# Altium schematic sheet Teensy 3.6 with J1939 with NFETs.SchDoc("Teensy 3.6 with J1939 with NFETs")
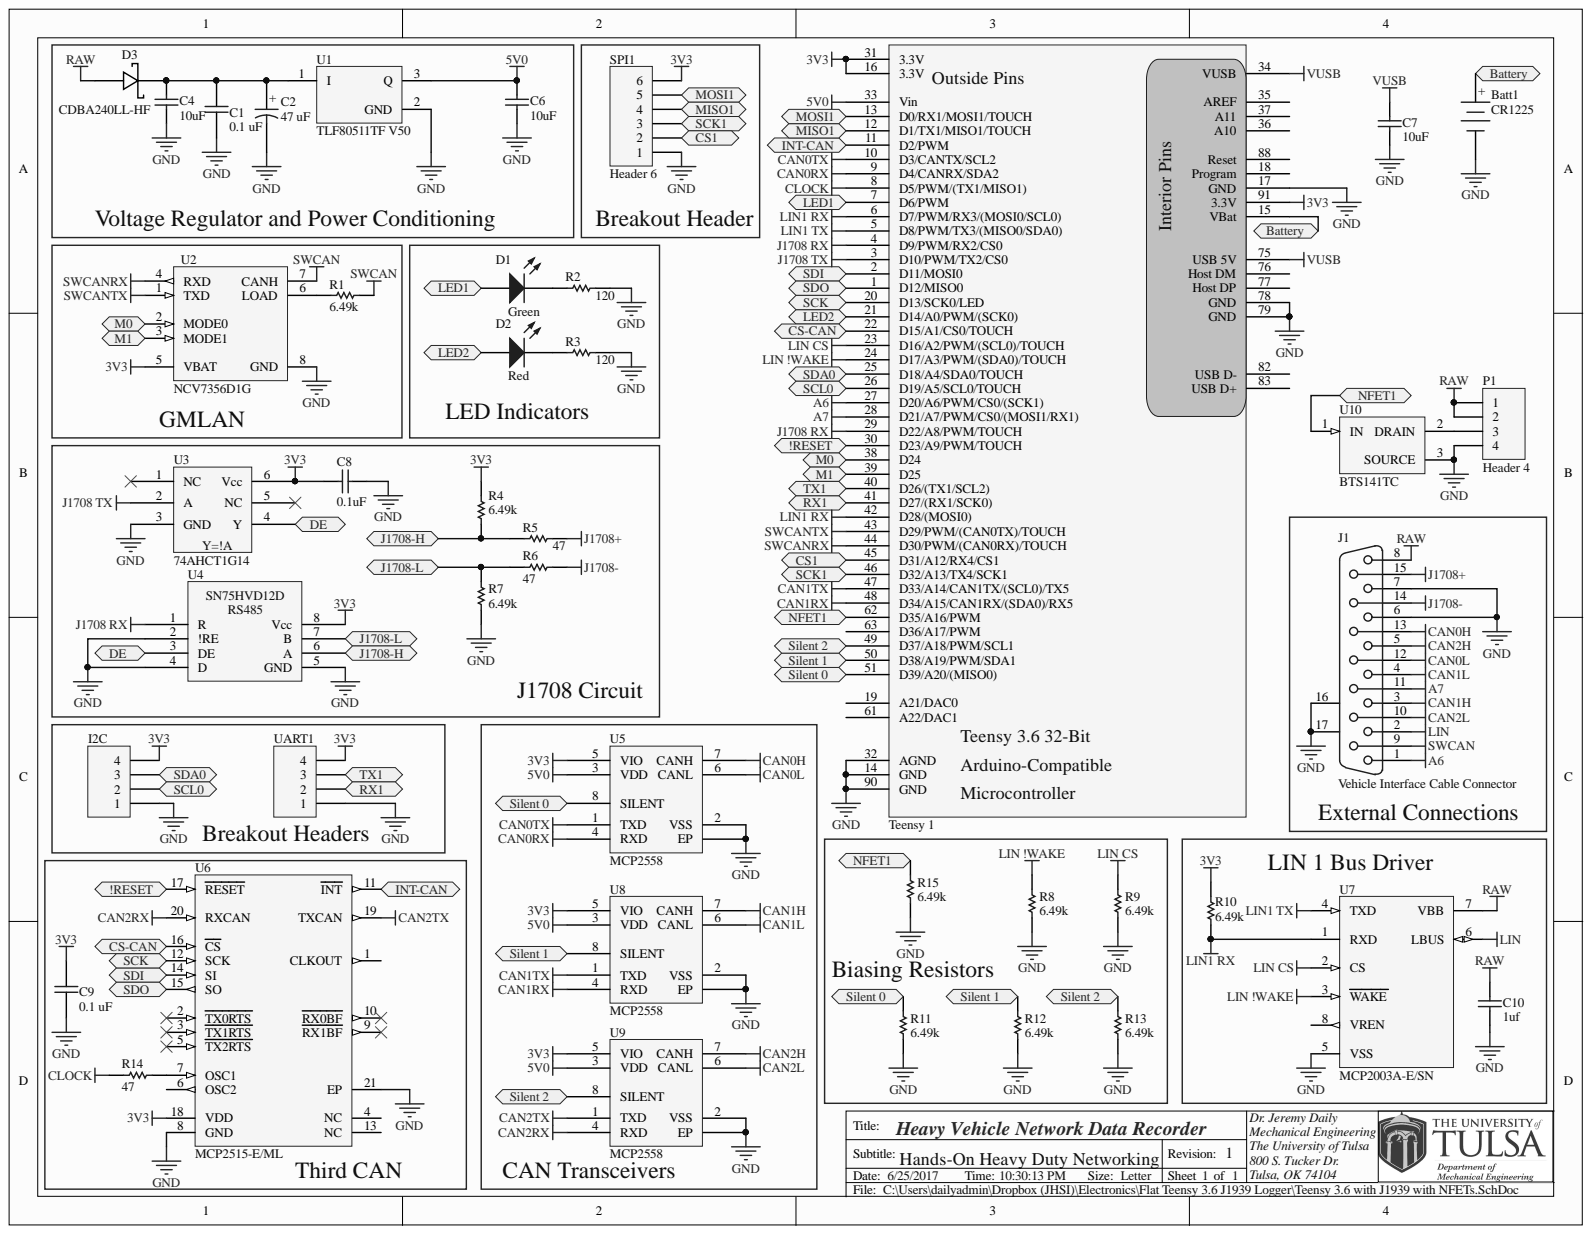
[diagram p0: Teensy 3.6 with J1939 with NFETs.SchDoc]

## Parts
Batt1  CR1225  [Battery Clip - CR1225]
C1  0.1 uF  [0603]
C2  47 uF  [2917]
C4  10uF  [1206]
C6  10uF  [1206]
C7  10uF  [1206]
C8  0.1uF  [0603]
C9  0.1 uF  [0603]
C10  1uf  [0603]
D1  Green  [0805 Polarized]
D2  Red  [0805 Polarized]
D3  CDBA240LL-HF  [SMA with A and K]
I2C  Header 4  [HDR1X4]  (value not drawn)
J1  Vehicle Interface Cable Connector  [DSUB1.385-2H15]
P1  Header 4  [HDR1X4]
R1  6.49k  [0603]
R2  120  [0603]
R3  120  [0603]
R4  6.49k  [0603]
R5  47  [0603]
R6  47  [0603]
R7  6.49k  [0603]
R8  6.49k  [0603]
R9  6.49k  [0603]
R10  6.49k  [0603]
R11  6.49k  [0603]
R12  6.49k  [0603]
R13  6.49k  [0603]
R14  47  [0603]
R15  6.49k  [0603]
SPI1  Header 6  [HDR1X6]
Teensy_1  PartNumber: Teensy 3.6  [Teensy 3.6 Through Hole - No Labels]  (hidden comment: Teensy 3.6 Through Hole)
U1  TLF80511TF V50  [INF-PG-TO252-3-11_N]
U2  NCV7356D1G  [ONSC-SOIC-8-751-07_V]
U3  74AHCT1G14  [SOT-353]
U4  SN75HVD08DR  [SOIC-SN8_N]  (value not drawn)
U5  MCP2558  [TDFN]
U6  MCP2515-E/ML  [QFN-ML20_M]
U7  MCP2003A-E/SN  [SOIC-SN8_N]
U8  MCP2558  [TDFN]
U9  MCP2558  [TDFN]
U10  BTS141TC  [INF-PG-TO263-3-2_N]
UART1  Header 4  [HDR1X4]  (value not drawn)

## Ports
!RESET, Battery, CS1, CS-CAN, DE, INT-CAN, J1708-H, J1708-L, LED1, LED2, M0, M1, MISO1, MOSI1, NFET1, RX1, SCK, SCK1, SCL0, SDA0, SDI, SDO, Silent 0, Silent 1, Silent 2, TX1

## Nets
3V3: C8.2 C9.2 I2C.4 R4.1 R10.2 SPI1.6 Teensy_1.16(3.3V) Teensy_1.31(3.3V) Teensy_1.91(3.3V) U2.5(VBAT) U3.6(Vcc) U4.8(Vcc) U5.5(VIO) U6.18(VDD) U8.5(VIO) U9.5(VIO) UART1.4
5V0: C6.2 Teensy_1.33(Vin) U1.3 U5.3(VDD) U8.3(VDD) U9.3(VDD)
A6: J1.1 Teensy_1.27(D20/A6/PWM/CS0/(SCK1))
A7: J1.11 Teensy_1.28(D21/A7/PWM/CS0/(MOSI1/RX1))
CAN0H: J1.13 U5.7(CANH)
CAN0L: J1.12 U5.6(CANL)
CAN0RX: Teensy_1.9(D4/CANRX/SDA2) U5.4(RXD)
CAN0TX: Teensy_1.10(D3/CANTX/SCL2) U5.1(TXD)
CAN1H: J1.3 U8.7(CANH)
CAN1L: J1.4 U8.6(CANL)
CAN1RX: Teensy_1.48(D34/A15/CAN1RX/(SDA0)/RX5) U8.4(RXD)
CAN1TX: Teensy_1.47(D33/A14/CAN1TX/(SCL0)/TX5) U8.1(TXD)
CAN2H: J1.5 U9.7(CANH)
CAN2L: J1.10 U9.6(CANL)
CAN2RX: U6.20(RXCAN) U9.4(RXD)
CAN2TX: U6.19(TXCAN) U9.1(TXD)
CLOCK: R14.1 Teensy_1.8(D5/PWM/(TX1/MISO1))
GND: Batt1.2 C1.1 C2.2 C4.1 C6.1 C7.2 C8.1 C9.1 C10.2 I2C.1 J1.6 J1.7 J1.16 J1.17 P1.4 R2.2 R3.2 R7.2 R8.2 R9.2 R11.2 R12.2 R13.2 R15.2 SPI1.1 Teensy_1.14(GND) Teensy_1.17(GND) Teensy_1.32(AGND) Teensy_1.78(GND) Teensy_1.79(GND) Teensy_1.90(GND) U1.2 U2.8(GND) U3.3(GND) U4.2(!RE) U4.4(D) U4.5(GND) U5.0(EP) U5.2(VSS) U6.8(GND) U6.21(EP) U7.5(VSS) U8.0(EP) U8.2(VSS) U9.0(EP) U9.2(VSS) U10.3 UART1.1
J1708 RX: Teensy_1.4(D9/PWM/RX2/CS0) Teensy_1.29(D22/A8/PWM/TOUCH) U4.1(R)
J1708 TX: Teensy_1.3(D10/PWM/TX2/CS0) U3.2(A)
J1708+: J1.15 R5.2
J1708-: J1.14 R6.2
LIN: J1.2 U7.6(LBUS)
LIN1 RX: R10.1 Teensy_1.6(D7/PWM/RX3/(MOSI0/SCL0)) Teensy_1.42(D28/(MOSI0)) U7.1(RXD)
LIN1 TX: Teensy_1.5(D8/PWM/TX3/(MISO0/SDA0)) U7.4(TXD)
LIN !WAKE: R8.1 Teensy_1.24(D17/A3/PWM/(SDA0)/TOUCH) U7.3(WAKE)
LIN CS: R9.1 Teensy_1.23(D16/A2/PWM/(SCL0)/TOUCH) U7.2(CS)
RAW: C10.1 D3.1 J1.8 P1.1 P1.2 U7.7(VBB)
SWCAN: J1.9 R1.2 U2.7(CANH)
SWCANRX: Teensy_1.44(D30/PWM/(CAN0RX)/TOUCH) U2.4(RXD)
SWCANTX: Teensy_1.43(D29/PWM/(CAN0TX)/TOUCH) U2.1(TXD)
VUSB: C7.1 Teensy_1.34(VUSB) Teensy_1.75(USB_5V)
!RESET: Teensy_1.30(D23/A9/PWM/TOUCH) U6.17(RESET) ; port !RESET
Battery: Batt1.1 Teensy_1.15(VBat) ; port Battery
CS1: SPI1.2 Teensy_1.45(D31/A12/RX4/CS1) ; port CS1
CS-CAN: Teensy_1.22(D15/A1/CS0/TOUCH) U6.16(CS) ; port CS-CAN
DE: U3.4(Y) U4.3(DE) ; port DE
INT-CAN: Teensy_1.11(D2/PWM) U6.11(INT) ; port INT-CAN
J1708-H: R4.2 R5.1 U4.6(A) ; port J1708-H
J1708-L: R6.1 R7.1 U4.7(B) ; port J1708-L
LED1: D1.1 Teensy_1.7(D6/PWM) ; port LED1
LED2: D2.1 Teensy_1.21(D14/A0/PWM/(SCK0)) ; port LED2
M0: Teensy_1.38(D24) U2.2(MODE0) ; port M0
M1: Teensy_1.39(D25) U2.3(MODE1) ; port M1
MISO1: SPI1.4 Teensy_1.12(D1/TX1/MISO1/TOUCH) ; port MISO1
MOSI1: SPI1.5 Teensy_1.13(D0/RX1/MOSI1/TOUCH) ; port MOSI1
NFET1: R15.1 Teensy_1.62(D35/A16/PWM) U10.1 ; port NFET1
RX1: Teensy_1.41(D27/(RX1/SCK0)) UART1.2 ; port RX1
SCK: Teensy_1.20(D13/SCK0/LED) U6.12(SCK) ; port SCK
SCK1: SPI1.3 Teensy_1.46(D32/A13/TX4/SCK1) ; port SCK1
SCL0: I2C.2 Teensy_1.26(D19/A5/SCL0/TOUCH) ; port SCL0
SDA0: I2C.3 Teensy_1.25(D18/A4/SDA0/TOUCH) ; port SDA0
SDI: Teensy_1.2(D11/MOSI0) U6.14(SI) ; port SDI
SDO: Teensy_1.1(D12/MISO0) U6.15(SO) ; port SDO
Silent 0: R11.1 Teensy_1.51(D39/A20/(MISO0)) U5.8(SILENT) ; port Silent 0
Silent 1: R12.1 Teensy_1.50(D38/A19/PWM/SDA1) U8.8(SILENT) ; port Silent 1
Silent 2: R13.1 Teensy_1.49(D37/A18/PWM/SCL1) U9.8(SILENT) ; port Silent 2
TX1: Teensy_1.40(D26/(TX1/SCL2)) UART1.3 ; port TX1
NetC1_2: C1.2 C2.1 C4.2 D3.2 U1.1
NetD1_2: D1.2 R2.1
NetD2_2: D2.2 R3.1
NetP1_3: P1.3 U10.2
NetR1_1: R1.1 U2.6(LOAD)
NetR14_2: R14.2 U6.7(OSC1)
NetTeensy 1_63: Teensy_1.63(D36/A17/PWM)

Not connected: Teensy_1 18(Program) 19(A21/DAC0) 35(AREF) 36(A10) 37(A11) 61(A22/DAC1) 76(Host_DM) 77(Host_DP) 82(USB_D-) 83(USB_D+) 88(Reset); U3 1(NC) 5(NC); U6 1(CLKOUT) 2(TX0RTS) 3(TX1RTS) 4(NC) 5(TX2RTS) 6(OSC2) 9(RX1BF) 10(RX0BF) 13(NC); U7 8(VREN)

## Library data of the parts
Batt1 = CR2032 Battery Holder: Lithium Battery Non-Rechargeable (Primary) 3V Coin, 12.5mm; PartNumber: BAT-HLD-012-SMT; Manufacturer: Linx; Supplier Part Number 1: BAT-HLD-012-SMT-ND; Supplier 1: Digi-Key; Library Name: SSS Schematic Library.SchLib; Component Kind: Standard
C1 C9 = Cap: CAP CER 0.1UF 50V X7R 0603; PartNumber: GRM188R71H104KA93D; Manufacturer: Murata Electronics North America; Supplier Part Number 1: 490-1519-1-ND; Supplier 1: Digi-Key; Library Name: SSS Integrated Library.IntLib; Component Kind: Standard
C2 = Tantalum Cap_1: Tantalum Capacitors - Solid SMD 35volts 47uF 10% ESR=300mOhms; PartNumber: T495X476K035ATE300; Manufacturer: Kemet; Supplier Part Number 1: 399-3905-1-ND; Supplier 1: Digi-Key; Library Name: SSS Schematic Library.SchLib; Component Kind: Standard
C4 C6 C7 = Cap: 10µF ±20% 50V Ceramic Capacitor X5R 1206 (3216 Metric); PartNumber: GRT31CR61H106ME01L; Manufacturer: Murata Electronics North America; Supplier Part Number 1: 490-12457-1-ND; Supplier 1: Digi-Key; Simulation: CAP; Signal Integrity: Cap; Library Name: SSS Integrated Library.IntLib; Component Kind: Standard
C8 = Cap: CAP CER 0.1UF 50V 10% X7R 0603; PartNumber: GRM188R71H104KA93D; Manufacturer: Murata Electronics North America; Supplier Part Number 1: 490-1519-1-ND; Supplier 1: Digi-Key; Simulation: CAP; Signal Integrity: Cap; Library Name: SSS Schematic Library.SchLib; Component Kind: Standard
C10 = Cap: 1µF ±20% 50V Ceramic Capacitor X5R 0603 (1608 Metric); PartNumber: GRT188R61H105ME13D; Manufacturer: Murata Electronics North America; Supplier Part Number 1: 490-12331-1-ND; Supplier 1: Digi-Key; Simulation: CAP; Signal Integrity: Cap; Library Name: SSS Schematic Library.SchLib; Component Kind: Standard
D1 D2 = LED - SMD: LED RED DIFFUSED 0805 SMD; PartNumber: LS R976-NR-1; Manufacturer: OSRAM Opto Semiconductors Inc.; Supplier Part Number 1: 475-1278-1-ND; Supplier 1: Digi-Key; Library Name: SSS Integrated Library.IntLib; Component Kind: Standard
D3 = Schottky Diode: Diode Schottky 40V 2A Surface Mount DO-214AC (SMA); Manufacturer: Comchip Technology; Supplier Part Number 1: 641-1698-1-ND; Supplier 1: Digi-Key; PartNumber: CDBA240LL-HF; Library Name: SSS Integrated Library.IntLib; Component Kind: Standard
I2C P1 UART1 = Header 4: Header, 4-Pin; Signal Integrity: Connector; Library Name: Miscellaneous Connectors.IntLib; Component Kind: Standard
  P1: LatestRevisionNote: Re-released for DXP Platform.; LatestRevisionDate: 17-Jul-2002; Publisher: Altium Limited
J1 = D Connector 15: Receptacle Assembly, 15 Position, Right Angle; PartNumber: D15S33E4GV00LF; Manufacturer: Amphenol FCI; Supplier Part Number 1: 609-1498-ND; Supplier 1: Digi-Key; Signal Integrity: Connector; Library Name: Miscellaneous Connectors.IntLib; Component Kind: Standard
R1 R4 R7-R13 R15 = Chip Resistor: 6.49k Ohm ±1% 0.1W, 1/10W Chip Resistor 0603 (1608 Metric) Automotive AEC-Q200 Thick Film; PartNumber: ERJ-3EKF6491V; Manufacturer: Panasonic Electronic Components; Supplier Part Number 1: P6.49KHCT-ND; Supplier 1: Digi-Key; Library Name: SSS Integrated Library.IntLib; Component Kind: Standard
R2 R3 = Chip Resistor: 120 Ohm ±1% 0.1W, 1/10W Chip Resistor 0603 (1608 Metric) Automotive AEC-Q200 Thick Film; PartNumber: ERJ-3EKF1200V; Manufacturer: Panasonic Electronic Components; Supplier Part Number 1: P120HCT-ND; Supplier 1: Digi-Key; Library Name: SSS Integrated Library.IntLib; Component Kind: Standard
R5 R6 R14 = Chip Resistor: RES SMD 47 OHM 1% 1/10W 0603; PartNumber: ERJ-3EKF47R0V; Manufacturer: Panasonic Electronic Components; Supplier Part Number 1: P47.0HCT-ND; Supplier 1: Digi-Key; Library Name: SSS Integrated Library.IntLib; Component Kind: Standard
SPI1 = Header 6: Header, 6-Pin; Signal Integrity: Connector; Library Name: Miscellaneous Connectors.IntLib; Component Kind: Standard
Teensy_1 = Teensy 3.6 Through Hole: 32 Bit Arduino-Compatible Microcontroller; Manufacturer: PRJC; Supplier Part Number 1: 1568-1442-ND; Supplier 1: Digi-Key; Library Name: Teensy.IntLib; Component Kind: Standard
U1 = TLF80511TF V50: Low Dropout Linear Fixed Voltage Regulator, 5 Vout, -40 to 150 degC, PG-TO252-3, Reel, Green; PartNumber: TLF80511TFV50ATMA1; Manufacturer: Infineon; Supplier Part Number 1: TLF80511TFV50ATMA1CT-ND; Supplier 1: Digi-Key; Library Name: Infineon_Power_Supply_Voltage_Regulator.IntLib; Component Kind: Standard
U2 = NCV7356D1G: Single Wire CAN Transceiver, 5 to 27 V, 8-Pin SOIC, Pb-Free, Tube; Manufacturer: On Semiconductor; Supplier Part Number 1: NCV7356D1GOS-ND; Supplier 1: Digi-Key; PartNumber: NCV7356D1G; Component Kind: Standard; Library Name: ON Semi Signal Management Modems & Transceivers.IntLib
U3 = 74AHCT1G14 Inverter: IC SCHMIT-TRIGG INV GATE SOT353; PartNumber: 74AHCT1G14SE-7; Manufacturer: Diodes Incorporated; Supplier Part Number 1: 74AHCT1G14SE-7DICT-ND; Supplier 1: Digi-Key; Library Name: SSS Schematic Library.SchLib; Component Kind: Standard
U4 = SN75HVD12D (3.3v RS485): IC RS485 TXRX 3/5V 8-SOIC; Manufacturer: Texas Instruments; Supplier Part Number 1: 296-37893-1-ND; Supplier 1: Digi-Key; PartNumber: SN75HVD08DR; Library Name: SSS Integrated Library.IntLib; Component Kind: Standard
U5 U8 U9 = MCP2558FD: Microchip CAN FD Transceiver with Silent Mode; PartNumber: MCP2558FDT-H/MNY; Supplier Part Number 1: MCP2558FDT-H/MNYCT-ND; Supplier 1: Digi-Key; Manufacturer: Microchip; Library Name: TU Custom Parts Schematics.SchLib; Component Kind: Standard
U6 = MCP2515-E/ML: CAN Controller CAN 2.0 SPI Interface 20-QFN (4x4); Manufacturer: Microchip; Supplier Part Number 1: MCP2515-E/ML-ND; Supplier 1: Digi-Key; PartNumber: MCP2515-E/ML; Library Name: Microchip Interface.IntLib; Component Kind: Standard
U7 = MCP2003AT-E/SN: LIN J2602 Transceiver, 8-Pin SOIC, Extended Temperature, Tape and Reel; Manufacturer: Microchip; Supplier Part Number 1: MCP2003A-E/SN-ND; Supplier 1: Digi-Key; PartNumber: MCP2003A-E/SN; Library Name: Microchip Interface.IntLib; Component Kind: Standard
U10 = BTS141TC: Smart Low-Side Power Switch HITFET, 60 V VDS, 12 A ID, -40 to 150 degC, PG-TO263-3-2, Reel, Green; Manufacturer: Infineon; VDS (max): 60 V; ton (max): 100 us; toff (max): 170 us; RDS (on)(max): 30 mOhm; Ptot (max): 149 mW; ISC (max): 100 A; ID(nom): 5.1 A; ID(lim) (min): 25 A; ID: 12 A; Green: TRUE; Channels: 1; Supplier Part Number 4: BTS141TCDKR-ND; Supplier Part Number 3: BTS141TCCT-ND; Supplier Part Number 2: BTS141TCTR-ND; Supplier Part Number 1: 726-BTS141TC; Supplier 4: Digi-Key; Supplier 3: Digi-Key; Supplier 2: Digi-Key; Supplier 1: Mouser; PartNumber: BTS141TC; Packing: Reel; PackageVersion: Jul-09; PackageReference: PG-TO263-3-2; PackageDescription: 3-Pin Plastic (Green) Transistor Single Outline Package, 10 x 9.25 mm Body, 2.54 mm Pitch; Mounting Technology: Surface Mount; Library Name: Infineon_Power_Smart_Low-Side_&_High-Side_Switches.IntLib; Halogen-free: FALSE; Group: HITFET; DatasheetVersion: Rev. 1.0; ComponentLink3URL: http://www.infineon.com/dgdl/BTS141TC_DS_10.pdf?folderId=db3a3043163797a6011667aa084c0e01&fileId=db3a3043242ebc9e01242f43f58b003e&location=en.Products.Automotive_ICs.Smart_High-_and_Low-Side_Switches.HITFET___TEMPFET__-_Smart_Low-Side_Switches.DATASHEET.BTS141TC_DS_10.pdf; ComponentLink3Description: Package Specification; ComponentLink2URL: http://www.infineon.com/dgdl/BTS141TC_DS_10.pdf?folderId=db3a3043163797a6011667aa084c0e01&fileId=db3a3043242ebc9e01242f43f58b003e&location=en.Products.Automotive_ICs.Smart_High-_and_Low-Side_Switches.HITFET___TEMPFET__-_Smart_Low-Side_Switches.DATASHEET.BTS141TC_DS_10.pdf; ComponentLink2Description: Datasheet; ComponentLink1URL: http://www.infineon.com/; ComponentLink1Description: Manufacturer URL; Component Kind: Standard
